# Altium schematic sheet Interfaces_2.SchDoc
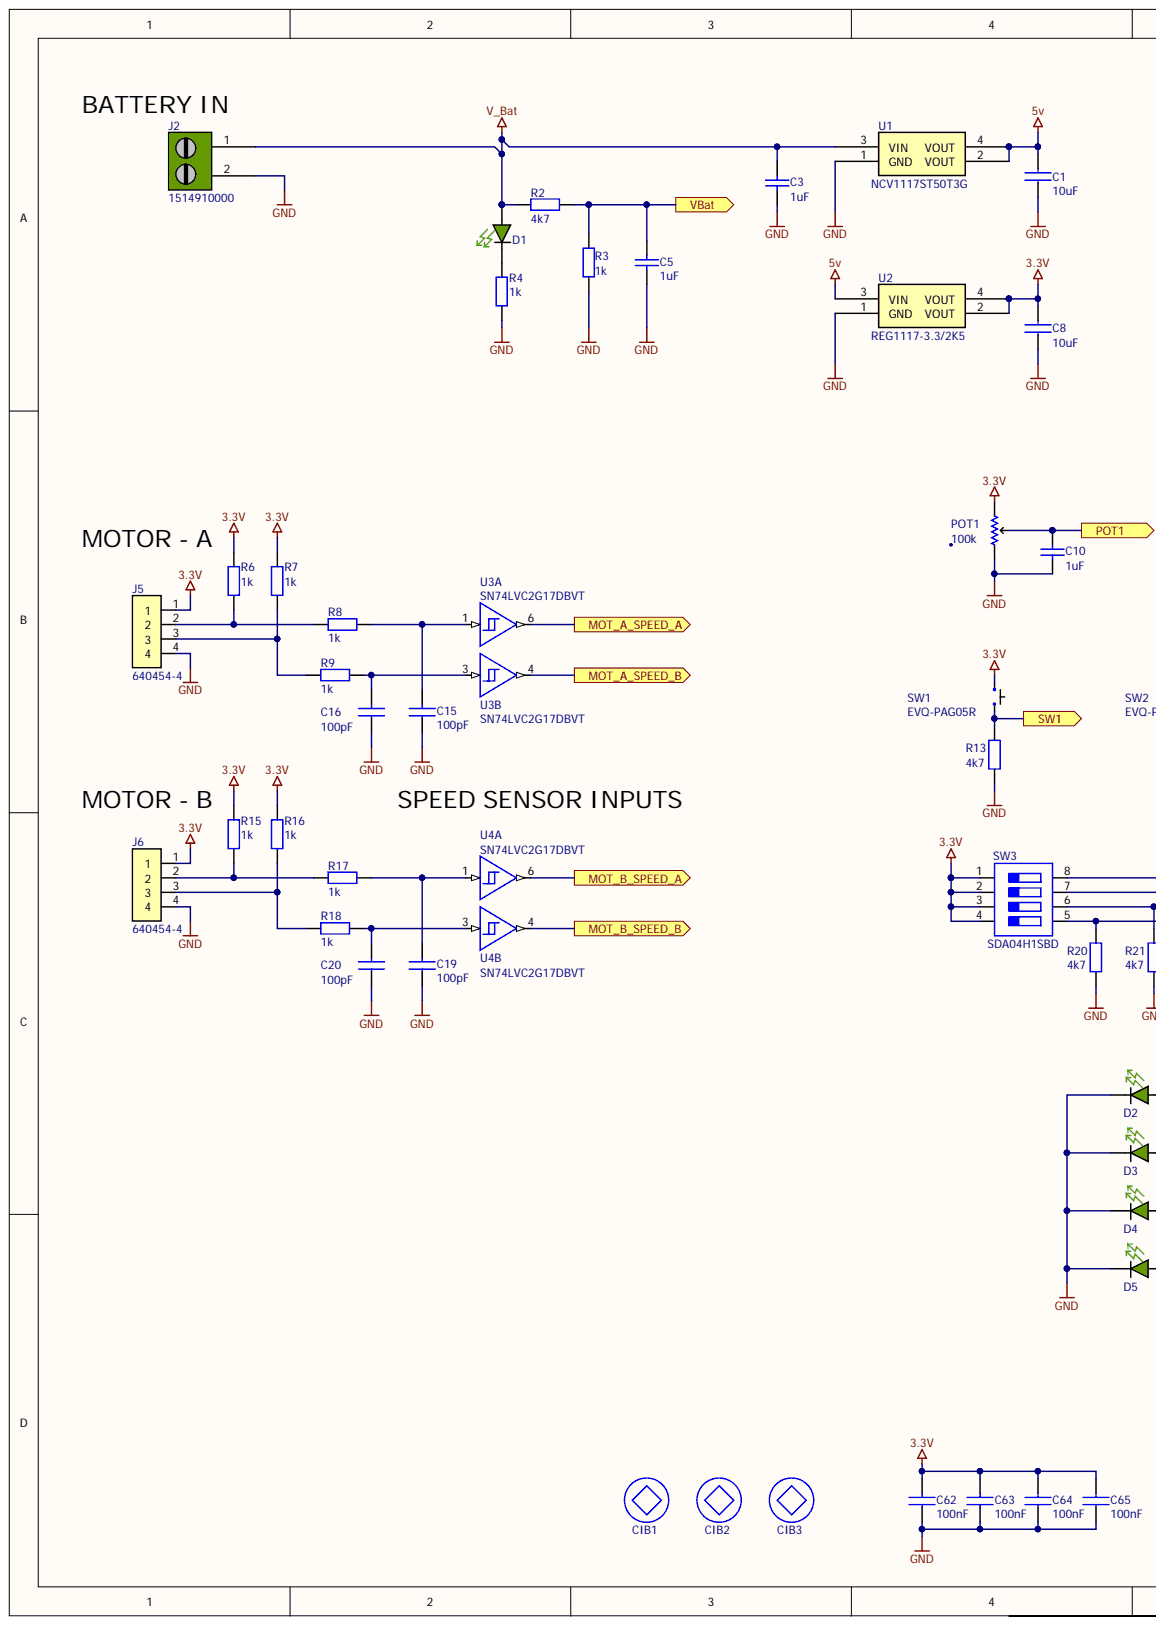
[diagram p0: Interfaces_2.SchDoc, left]
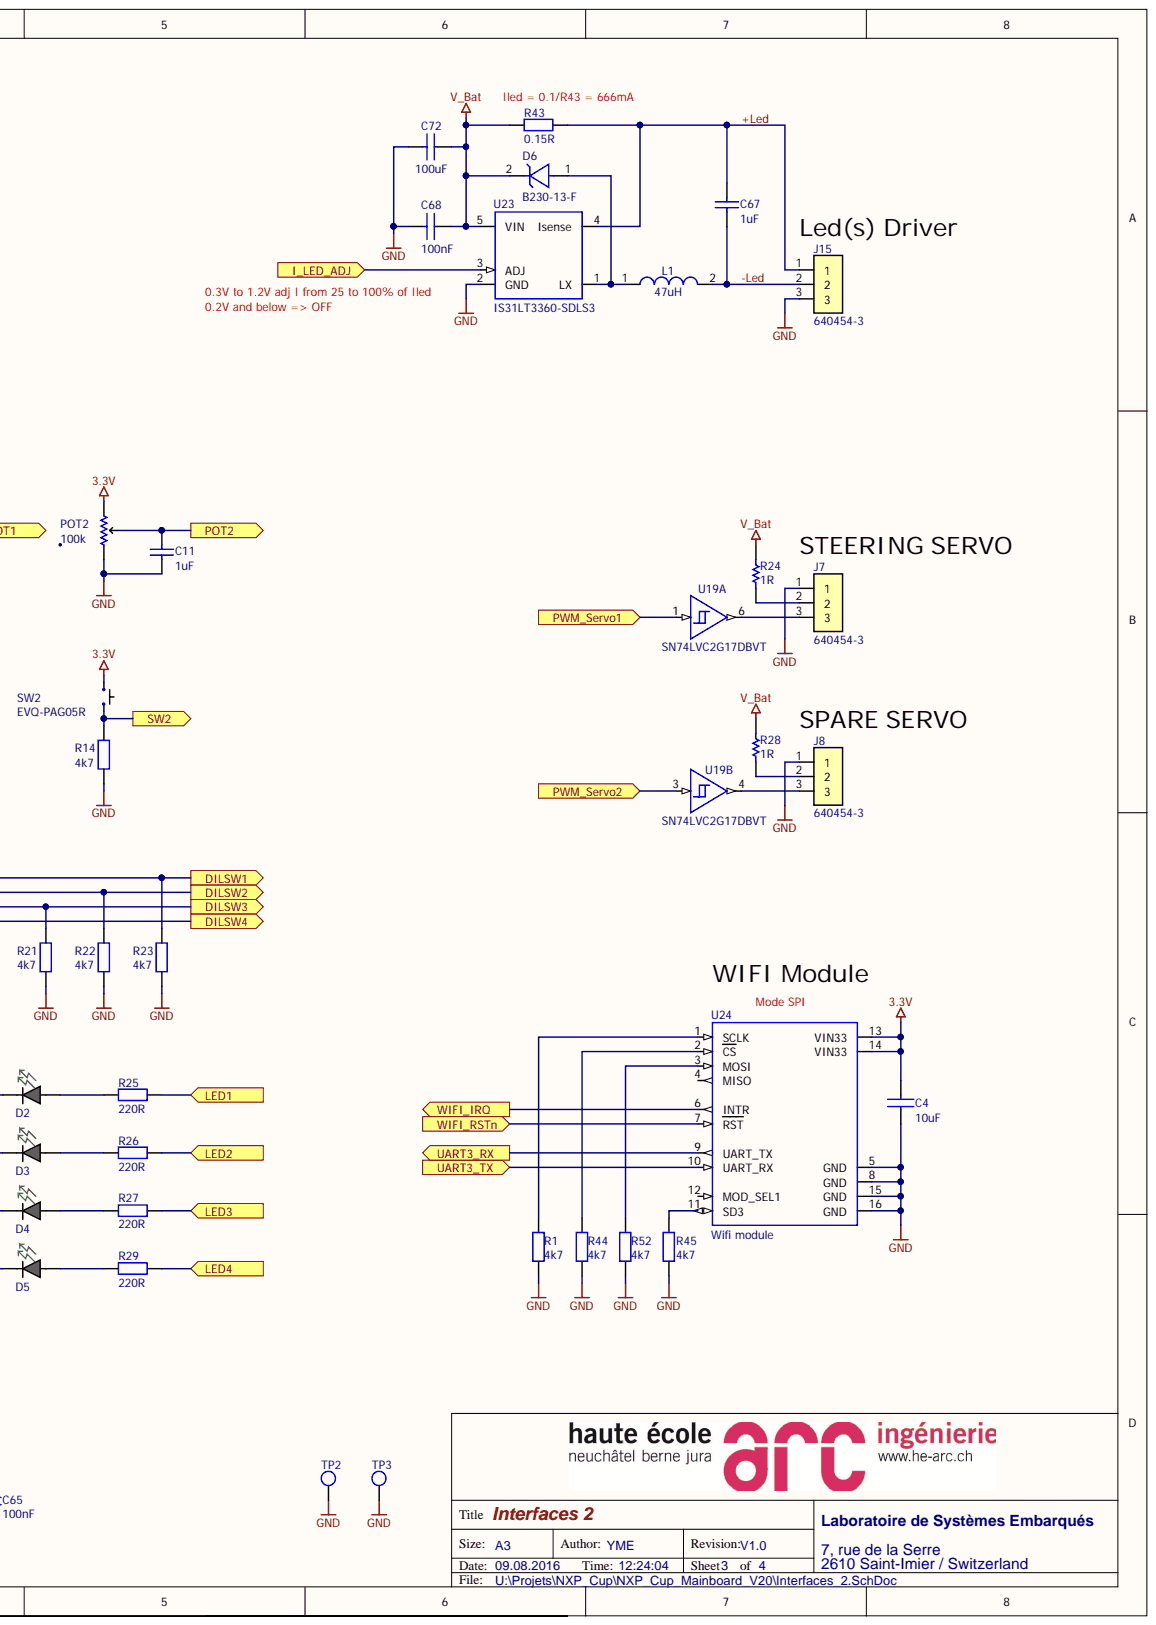
[diagram p1: Interfaces_2.SchDoc, right]

## Parts
C1  10uF  [080501]
C3  1uF  [060301]
C4  10uF  [080501]
C5  1uF  [060301]
C8  10uF  [080501]
C10  1uF  [060301]
C11  1uF  [060301]
C15  100pF  [060301]
C16  100pF  [060301]
C19  100pF  [060301]
C20  100pF  [060301]
C62  100nF  [060301]
C63  100nF  [060301]
C64  100nF  [060301]
C65  100nF  [060301]
C67  1uF  [060301]
C68  100nF  [060301]
C72  100uF  [121001]
CIB1  CIBLE  [CIBLERON]  (value not drawn)
CIB2  CIBLE  [CIBLERON]  (value not drawn)
CIB3  CIBLE  [CIBLERON]  (value not drawn)
D1  APT2012CGCK  [LED_0805 - GREEN]  (value not drawn)
D2  APT2012CGCK  [LED_0805 - GREEN]  (value not drawn)
D3  APT2012SRCPRV  [LED_0805 - GREEN]  (value not drawn)
D4  APT2012LVBC/D  [LED_0805 - GREEN]  (value not drawn)
D5  APT2012SYCK  [LED_0805 - GREEN]  (value not drawn)
D6  B230-13-F  [SMA]
J2  1514910000  [SL508_2C]
J5  640454-4  [640454-4]
J6  640454-4  [640454-4]
J7  640454-3  [640454-3]
J8  640454-3  [640454-3]
J15  640454-3  [640454-3]
L1  value 47uH  [SRN6045]  (hidden comment: SRN6045-470M)
POT1  100k  [3386P]
POT2  100k  [3386P]
R1  4k7  [060301]
R2  4k7  [060301]
R3  1k  [060301]
R4  1k  [060301]
R6  1k  [060301]
R7  1k  [060301]
R8  1k  [060301]
R9  1k  [060301]
R13  4k7  [060301]
R14  4k7  [060301]
R15  1k  [060301]
R16  1k  [060301]
R17  1k  [060301]
R18  1k  [060301]
R20  4k7  [060301]
R21  4k7  [060301]
R22  4k7  [060301]
R23  4k7  [060301]
R24  1R  [R2512]
R25  220R  [060301]
R26  220R  [060301]
R27  220R  [060301]
R28  1R  [R2512]
R29  220R  [060301]
R43  0.15R  [120601]
R44  4k7  [060301]
R45  4k7  [060301]
R52  4k7  [060301]
SW1  EVQ-PAG05R  [EVQ-PAG05R]
SW2  EVQ-PAG05R  [EVQ-PAG05R]
SW3  SDA04H1SBD  [GDS04]
TP2  TEST POINT PC MINI .040"D BLACK  [TP_20_10]  (value not drawn)
TP3  TEST POINT PC MINI .040"D BLACK  [TP_20_10]  (value not drawn)
U1  NCV1117ST50T3G  [SOT223]
U2  REG1117-3.3/2K5  [SOT223]
U3  SN74LVC2G17DBVT  [SOT23601]  (parts A,B)
U4  SN74LVC2G17DBVT  [SOT23601]  (parts A,B)
U19  SN74LVC2G17DBVT  [SOT23601]  (parts A,B)
U23  IS31LT3360-SDLS3  [SOT89-5]
U24  Wifi module  [Module_wifi_2]

## Ports
DILSW1, DILSW2, DILSW3, DILSW4, I_LED_ADJ, LED1, LED2, LED3, LED4, MOT_A_SPEED_A, MOT_A_SPEED_B, MOT_B_SPEED_A, MOT_B_SPEED_B, POT1, POT2, PWM_SERVO1, PWM_SERVO2, SW1, SW2, UART3_RX, UART3_TX, VBAT, WIFI_IRQ, WIFI_RSTN

## Nets
3.3V: C4.2 C8.2 C62.2 C63.2 C64.2 C65.2 J5.1 J6.1 POT1.1 POT2.1 R6.2 R7.2 R15.2 R16.2 SW1.A SW2.A SW3.1 SW3.2 SW3.3 SW3.4 U2.2(VOUT) U2.4(VOUT) U3.5 U4.5 U19.5 U24.13(VIN33) U24.14(VIN33)
5V: C1.2 U1.2(VOUT) U1.4(VOUT) U2.3(VIN)
GND: C1.1 C3.2 C4.1 C5.2 C8.1 C10.2 C11.2 C15.1 C16.1 C19.1 C20.1 C62.1 C63.1 C64.1 C65.1 C68.2 C72.2 D2.C D3.C D4.C D5.C J2.2 J5.4 J6.4 J7.1 J8.1 J15.3 POT1.3 POT2.3 R1.1 R3.2 R4.1 R13.1 R14.1 R20.1 R21.1 R22.1 R23.1 R44.1 R45.2 R52.1 TP2.1 TP3.1 U1.1(GND) U2.1(GND) U3.2 U4.2 U19.2 U23.2(GND) U24.5(GND) U24.8(GND) U24.15(GND) U24.16(GND)
PWM_SERVO1: U19.1 ; port PWM_SERVO1
PWM_SERVO2: U19.3 ; port PWM_SERVO2
V_BAT: C3.1 C68.1 C72.1 D1.A D6.2 J2.1 R2.2 R24.2 R28.2 R43.2 U1.3(VIN) U23.5(VIN)
VBAT: C5.1 R2.1 R3.1 ; port VBAT
WIFI_RSTN: U24.7(RST) ; port WIFI_RSTN
+LED: C67.1 J15.1 R43.1 U23.4(Isense)
-LED: C67.2 J15.2 L1.2
DILSW1: R23.2 SW3.8 ; port DILSW1
DILSW2: R22.2 SW3.7 ; port DILSW2
DILSW3: R21.2 SW3.6 ; port DILSW3
DILSW4: R20.2 SW3.5 ; port DILSW4
I_LED_ADJ: U23.3(ADJ) ; port I_LED_ADJ
LED1: R25.1 ; port LED1
LED2: R26.1 ; port LED2
LED3: R27.1 ; port LED3
LED4: R29.1 ; port LED4
MOT_A_SPEED_A: U3.6 ; port MOT_A_SPEED_A
MOT_A_SPEED_B: U3.4 ; port MOT_A_SPEED_B
MOT_B_SPEED_A: U4.6 ; port MOT_B_SPEED_A
MOT_B_SPEED_B: U4.4 ; port MOT_B_SPEED_B
POT1: C10.1 POT1.2 ; port POT1
POT2: C11.1 POT2.2 ; port POT2
SW1: R13.2 SW1.B ; port SW1
SW2: R14.2 SW2.B ; port SW2
UART3_RX: U24.9(UART_TX) ; port UART3_RX
UART3_TX: U24.10(UART_RX) ; port UART3_TX
WIFI_IRQ: U24.6(INTR) ; port WIFI_IRQ
NetC15_2: C15.2 R8.1 U3.1
NetC16_2: C16.2 R9.1 U3.3
NetC19_2: C19.2 R17.1 U4.1
NetC20_2: C20.2 R18.1 U4.3
NetD1_C: D1.C R4.2
NetD2_A: D2.A R25.2
NetD3_A: D3.A R26.2
NetD4_A: D4.A R27.2
NetD5_A: D5.A R29.2
NetD6_1: D6.1 L1.1 U23.1(LX)
NetJ5_2: J5.2 R6.1 R8.2
NetJ5_3: J5.3 R7.1 R9.2
NetJ6_2: J6.2 R15.1 R17.2
NetJ6_3: J6.3 R16.1 R18.2
NetJ7_2: J7.2 R24.1
NetJ7_3: J7.3 U19.6
NetJ8_2: J8.2 R28.1
NetJ8_3: J8.3 U19.4
NetR1_2: R1.2 U24.1(SCLK)
NetR44_2: R44.2 U24.2(CS)
NetR45_1: R45.1 U24.11(SD3)
NetR52_2: R52.2 U24.3(MOSI)

Not connected: U24 4(MISO) 12(MOD_SEL1)

## Library data of the parts
C1 C4 C8 = CAPA: CAP CER 10UF 16V 20% X6S 0805; Component Kind: Standard; Supplier 1: Digi-Key; Supplier Part Number 1: 445-7612-2-ND; Value: 10uF; Library Name: EISI.SchLib
C3 C5 C10 C11 C67 = Cap Semi: CAP CER 1UF 16V 10% X7R 0603; Component Kind: Standard; Library Name: Miscellaneous Devices.IntLib; Signal Integrity: Cap Semi; Simulation: CAP; Supplier 1: Digi-Key; Supplier Part Number 1: 399-7847-6-ND; Value: 1uF
C15 C16 C19 C20 = CAPA: CAP CER 100PF 50V 5% NP0 0603; Component Kind: Standard; Supplier 1: Digi-Key; Supplier Part Number 1: 445-1281-1-ND; Value: 100pF; Voltage: 50V; Library Name: EISI.SchLib
C62-C65 C68 = CAPA: CAP CER 0.1UF 25V 10% X7R 0603; Component Kind: Standard; Supplier 1: Digi-Key; Supplier Part Number 1: 445-1316-1-ND; Value: 100nF; Voltage: 25V; Library Name: EISI.SchLib
C72 = CAPA: CAP CER 100UF 16V 20% X5R 1210; Component Kind: Standard; Supplier 1: Digi-Key; Supplier Part Number 1: 587-3152-1-ND; Value: 100uF; Library Name: EISI.SchLib
CIB1-CIB3 = CIBLE: Cible de positionnement pour montage PCB; Component Kind: Standard; Library Name: StartTimeV.SCHLIB
D1 D2 = APT2012CGCK: LED GREEN CLEAR 0805 SMD; Component Kind: Standard; Library Name: eDiode.SchLib; Supplier 1: Digi-Key; Supplier Part Number 1: 754-1127-1-ND
D3 = APT2012CGCK: LED RED CLEAR 0805 SMD; Component Kind: Standard; Library Name: eDiode.SchLib; Supplier 1: Digi-Key; Supplier Part Number 1: 754-1132-1-ND
D4 = APT2012CGCK: LED BLUE CLEAR 0805 SMD; Component Kind: Standard; Library Name: eDiode.SchLib; Supplier 1: Digi-Key; Supplier Part Number 1: 754-1938-1-ND
D5 = APT2012CGCK: LED YELLOW CLEAR 0805 SMD; Component Kind: Standard; Library Name: eDiode.SchLib; Supplier 1: Digi-Key; Supplier Part Number 1: 754-1134-1-ND
D6 = DIODE SCHOTTKY: DIODE SCHOTTKY 30V 2A SMA; Component Kind: Standard; Supplier 1: Digi-Key; Supplier Part Number 1: B230-FDICT-ND; Library Name: EISI.SchLib
J2 = 282857-2: TERM BLOCK HDR 2POS R/A 5.08MM; Voltage: 300V; Component Kind: Standard; Library Name: eConnector.SchLib; Supplier 1: Digi-Key; Supplier Part Number 1: 281-1279-ND; Value: 282857-2
J5 J6 = 640454-4: CONN HEADER VERT 4POS .100 TIN; Component Kind: Standard; Supplier 1: Digi-Key; Supplier Part Number 1: A19431-ND; Value: 640454-4; Library Name: FRDM-ARC.SCHLIB
J7 J8 J15 = 640454-3: CONN HEADER VERT 3POS .100 TIN; Component Kind: Standard; Supplier 1: Digi-Key; Supplier Part Number 1: A19430-ND; Value: 640454-3; Library Name: FRDM-ARC.SCHLIB
L1 = INDUCTOR: INDUCTOR POWER SHIELD 47UH SMD; Component Kind: Standard; Supplier 1: Digi-Key; Supplier Part Number 1: SRN6045-470MCT-ND; Library Name: EISI.SchLib
POT1 POT2 = 3386P-1-104TLF: TRIMMER 100K OHM 0.5W TH; Component Kind: Standard; Library Name: ePotentiometer.SchLib; Supplier 1: Digi-Key; Supplier Part Number 1: 3386P-104TLF-ND; Value: 100k
R1 R2 R13 R14 R20-R23 R44 R45 R52 = RES: RES 4.7K OHM 1/10W 1% 0603 SMD; Component Kind: Standard; Supplier 1: Digi-Key; Supplier Part Number 1: P4.70KHTR-ND; Value: 4k7; Library Name: EISI.SchLib
R3 R4 R6-R9 R15-R18 = RES: RES 1.00K OHM 1/10W 1% 0603 SMD; Component Kind: Standard; Supplier 1: Digi-Key; Supplier Part Number 1: 311-1.00KHRTR-ND; Value: 1k; Library Name: EISI.SchLib
R24 R28 = ERJ-1TYJ1R0U: RES 1.0 OHM 1W 5% 2512 SMD; Component Kind: Standard; Library Name: eResistors.SchLib; Supplier 1: Digi-Key; Supplier Part Number 1: PT1.0XCT-ND; Value: 1R
R25-R27 R29 = RES: RES 220 OHM 1/10W 1% 0603 SMD; Component Kind: Standard; Supplier 1: Digi-Key; Supplier Part Number 1: 311-220HRCT-ND; Value: 220R; Library Name: EISI.SchLib
R43 = RES: RES 0.15 OHM 1/2W 1% 1206 SMD; Component Kind: Standard; Supplier 1: Digi-Key; Supplier Part Number 1: 311-.15LYCT-ND; Value: 0.15R; Library Name: EISI.SchLib
SW1 SW2 = EVQ-PAG05R: SWITCH LT 6MM 260GF 5MM HEIGHT; Component Kind: Standard; Library Name: eElectroMechanical.SchLib; Supplier 1: Digi-Key; Supplier Part Number 1: P12195S-ND; Value: EVQ-PAG05R
SW3 = SW DIP-4: SWITCH DIP TOP SLIDE 4POS SMD; Component Kind: Standard; Supplier 1: Digi-Key; Supplier Part Number 1: CKN6068-ND; Library Name: EISI.SchLib
TP2 TP3 = TP: Test point black; Component Kind: Standard; Supplier 1: Digi-Key; Supplier Part Number 1: 5001K-ND; Library Name: StartTimeV.SCHLIB
U1 = NCV1117ST50T3G: Low Dropout (LDO) Regulators ANA 800mA LDO; Component Kind: Standard; Library Name: eIC.SchLib; Supplier 1: Digi-Key; Supplier Part Number 1: NCV1117ST50T3GOSCT-ND
U2 = NCV1117ST50T3G: IC REG LDO 3.3V 0.8A SOT223; Component Kind: Standard; Library Name: eIC.SchLib; Supplier 1: Digi-Key; Supplier Part Number 1: 296-21321-1-ND
U3 U4 U19 = SN74LVC2G17: IS BUFF DL SCHMIT TRIG SOT23-6; Component Kind: Standard; Supplier 1: Digi-Key; Supplier Part Number 1: 296-26621-2-ND; Library Name: EISI.SchLib
U23 = IS31LT3360: IC LED DRVR STEP DOWN 5SOT89; Component Kind: Standard; Supplier 1: Digi-Key; Supplier Part Number 1: 706-1159-1-ND; Library Name: EISI.SchLib
U24 = Wifi_HE-ARC: Monter barttte femelle 2x8; Component Kind: Standard; Supplier 1: Digi-Key; Supplier Part Number 1: 1212-1241-ND; Library Name: FRDM-ARC.SCHLIB
